# Altium schematic sheet DP83848I.SchDoc(DP83848I)
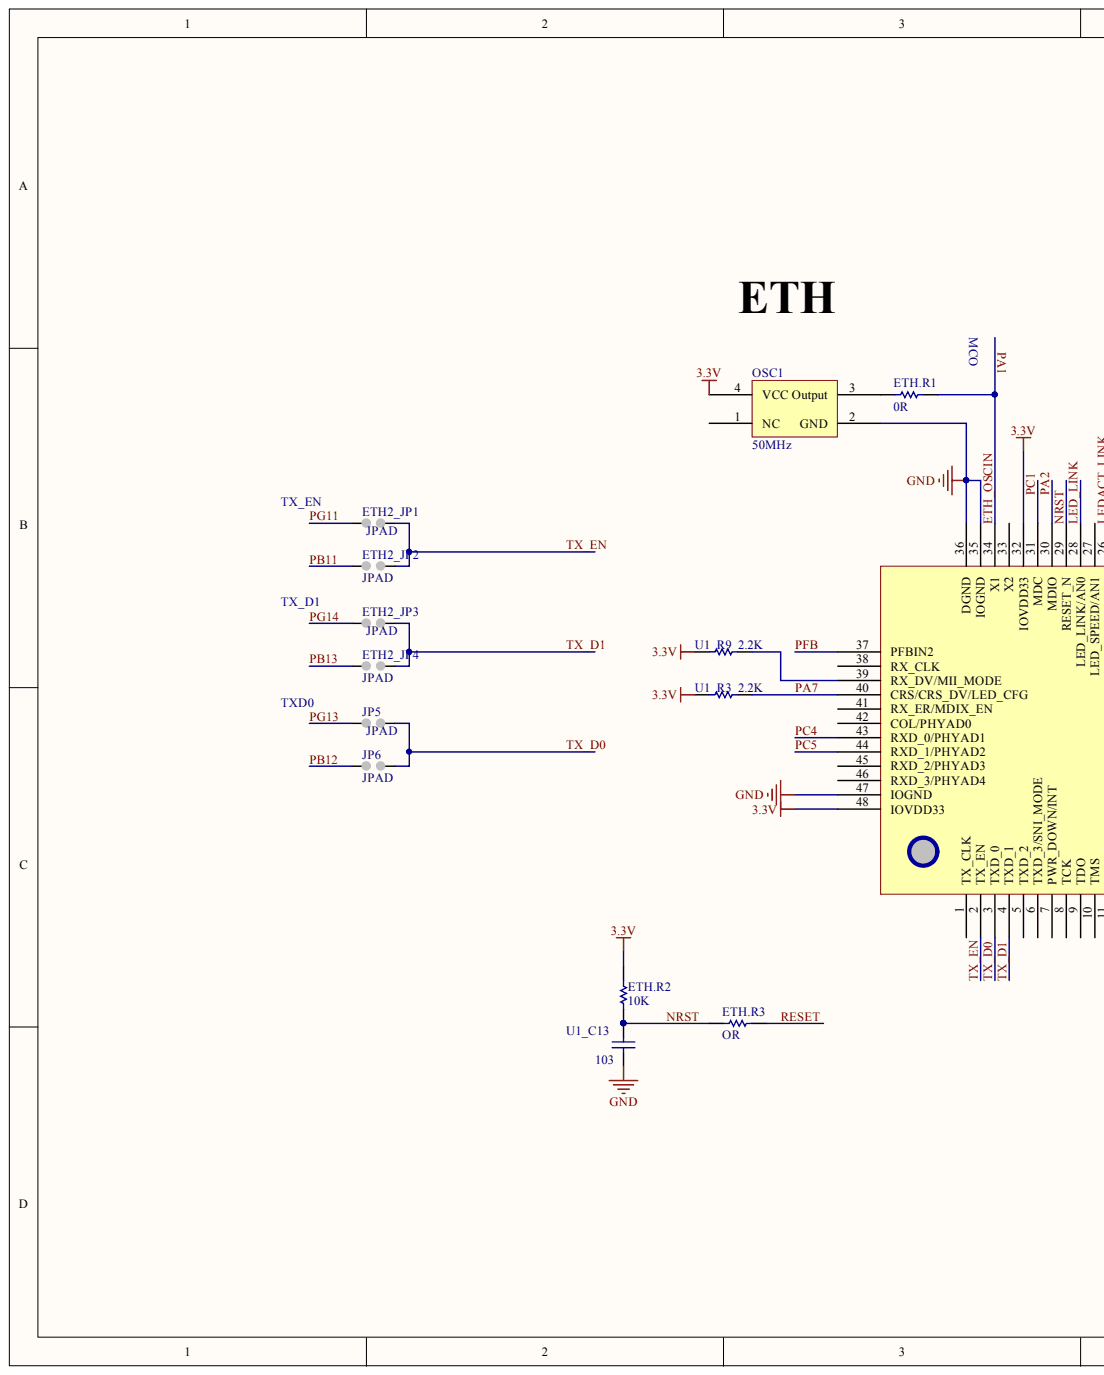
[diagram p0: DP83848I.SchDoc, left]
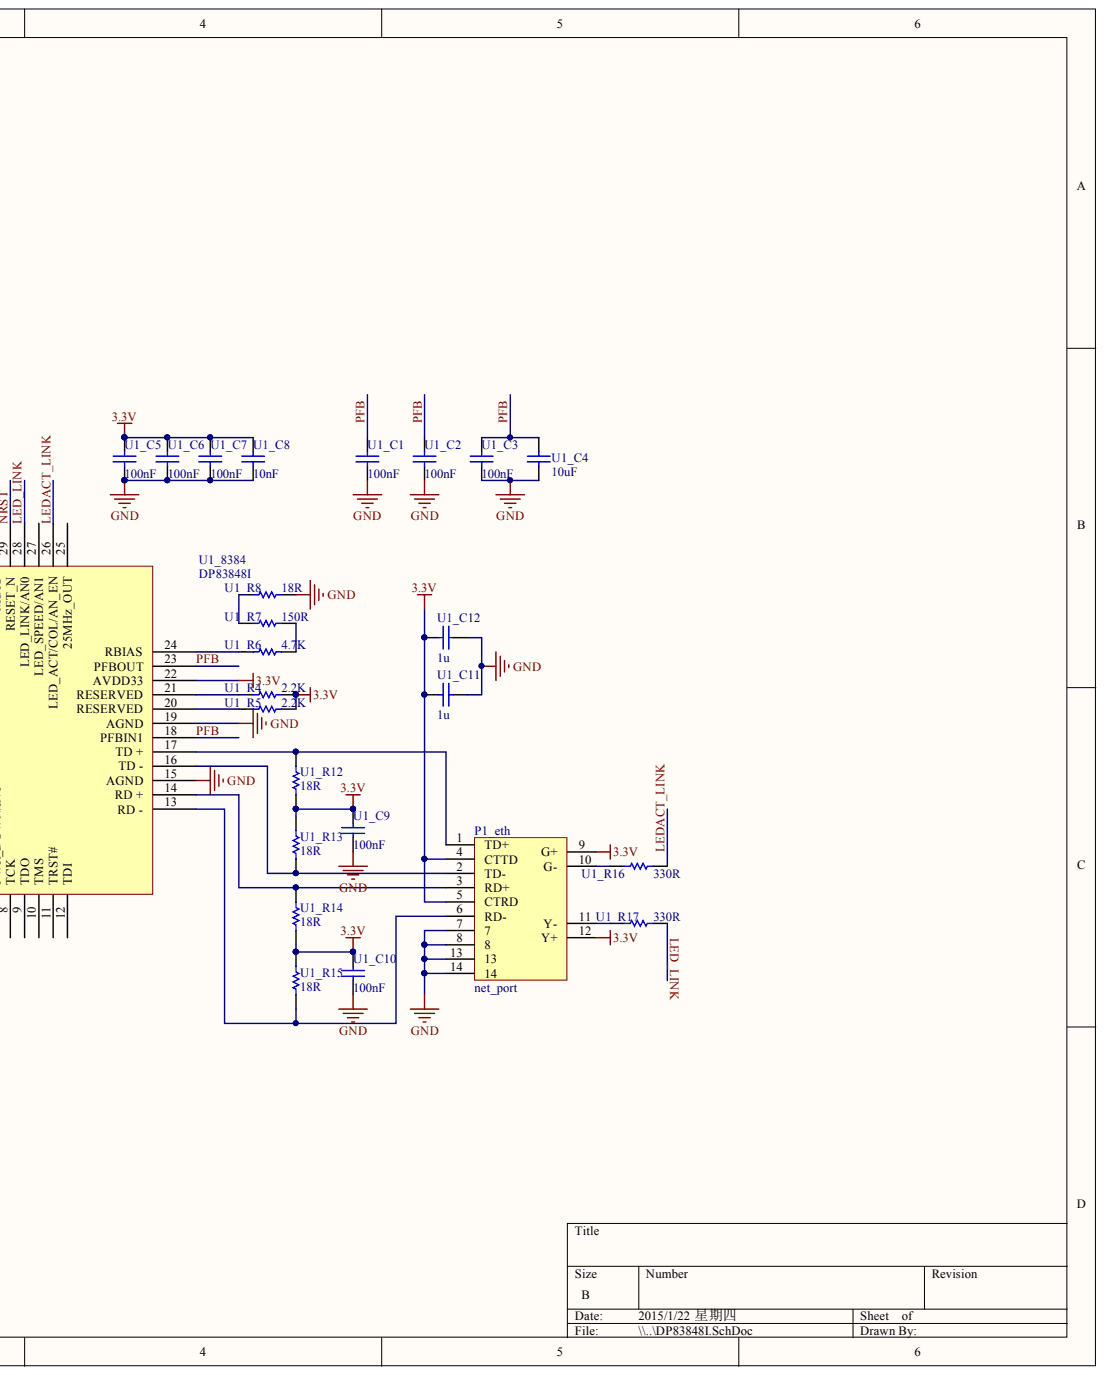
[diagram p1: DP83848I.SchDoc, right]

## Parts
ETH2_JP1  JPAD
ETH2_JP2  JPAD
ETH2_JP3  JPAD
ETH2_JP4  JPAD
ETH.R1  0R
ETH.R2  10K
ETH.R3  OR
JP5  JPAD
JP6  JPAD
OSC1  50MHz
P1_eth  net_port
U1_8384  DP83848I
U1_C1  100nF
U1_C2  100nF
U1_C3  100nF
U1_C4  10uF
U1_C5  100nF
U1_C6  100nF
U1_C7  100nF
U1_C8  10nF
U1_C9  100nF
U1_C10  100nF
U1_C11  value 1u  (hidden comment: C_0805)
U1_C12  value 1u  (hidden comment: C_0805)
U1_C13  value 103  (hidden comment: C_0805)
U1_R3  value 2.2K  (hidden comment: R_0805)
U1_R4  value 2.2K  (hidden comment: R_0805)
U1_R5  value 2.2K  (hidden comment: R_0805)
U1_R6  value 4.7K  (hidden comment: R_0805)
U1_R7  value 150R  (hidden comment: R_0805)
U1_R8  value 18R  (hidden comment: R_0805)
U1_R9  value 2.2K  (hidden comment: R_0805)
U1_R12  value 18R  (hidden comment: R_0805)
U1_R13  value 18R  (hidden comment: R_0805)
U1_R14  value 18R  (hidden comment: R_0805)
U1_R15  value 18R  (hidden comment: R_0805)
U1_R16  value 330R  (hidden comment: R_0805)
U1_R17  value 330R  (hidden comment: R_0805)

## Nets
3.3V: ETH.R2.2 OSC1.4(VCC) P1_eth.4(CTTD) P1_eth.5(CTRD) P1_eth.9(G+) P1_eth.12(Y+) U1_8384.22(AVDD33) U1_8384.32(IOVDD33) U1_8384.48(IOVDD33) U1_C5.2 U1_C6.2 U1_C7.2 U1_C8.2 U1_C9.2 U1_C10.2 U1_C11.2 U1_C12.2 U1_R3.1 U1_R4.2 U1_R5.2 U1_R9.1 U1_R12.1 U1_R13.2 U1_R14.1 U1_R15.1
GND: OSC1.2(GND) P1_eth.7 P1_eth.8 P1_eth.13 P1_eth.14 U1_8384.15(AGND) U1_8384.19(AGND) U1_8384.35(IOGND) U1_8384.36(DGND) U1_8384.47(IOGND) U1_C1.1 U1_C2.1 U1_C3.1 U1_C4.2 U1_C5.1 U1_C6.1 U1_C7.1 U1_C8.1 U1_C9.1 U1_C10.1 U1_C11.1 U1_C12.1 U1_C13.1 U1_R8.2
ETH_OSCIN: ETH.R1.1 U1_8384.34(X1)
LED_LINK: U1_8384.28(LED_LINK/AN0) U1_R17.2
LEDACT_LINK: U1_8384.26(LED_ACT/COL/AN_EN) U1_R16.2
NRST: ETH.R2.1 ETH.R3.2 U1_8384.29(RESET_N) U1_C13.2
PA2: U1_8384.30(MDIO)
PA7: U1_8384.40(CRS/CRS_DV/LED_CFG) U1_R3.2
PB11: ETH2_JP2.2
PB12: JP6.2
PB13: ETH2_JP4.2
PC1: U1_8384.31(MDC)
PC4: U1_8384.43(RXD_0/PHYAD1)
PC5: U1_8384.44(RXD_1/PHYAD2)
PFB: U1_8384.18(PFBIN1) U1_8384.23(PFBOUT) U1_8384.37(PFBIN2) U1_C1.2 U1_C2.2 U1_C3.2 U1_C4.1
PG11: ETH2_JP1.2
PG13: JP5.2
PG14: ETH2_JP3.2
RESET: ETH.R3.1
TX_D0: JP5.1 JP6.1 U1_8384.3(TXD_0)
TX_D1: ETH2_JP3.1 ETH2_JP4.1 U1_8384.4(TXD_1)
TX_EN: ETH2_JP1.1 ETH2_JP2.1 U1_8384.2(TX_EN)
NetETH.R1_2: ETH.R1.2 OSC1.3(Output)
NetP1_eth_1: P1_eth.1(TD+) U1_8384.17(TD_+) U1_R12.2
NetP1_eth_2: P1_eth.2(TD-) U1_8384.16(TD_-) U1_R13.1
NetP1_eth_3: P1_eth.3(RD+) U1_8384.14(RD_+) U1_R14.2
NetP1_eth_6: P1_eth.6(RD-) U1_8384.13(RD_-) U1_R15.2
NetP1_eth_10: P1_eth.10(G-) U1_R16.1
NetP1_eth_11: P1_eth.11(Y-) U1_R17.1
NetU1_8384_20: U1_8384.20(RESERVED) U1_R5.1
NetU1_8384_21: U1_8384.21(RESERVED) U1_R4.1
NetU1_8384_24: U1_8384.24(RBIAS) U1_R6.1
NetU1_8384_39: U1_8384.39(RX_DV/MII_MODE) U1_R9.2
NetU1_R6_2: U1_R6.2 U1_R7.2
NetU1_R7_1: U1_R7.1 U1_R8.1

Not connected: OSC1 1(NC); U1_8384 1(TX_CLK) 5(TXD_2) 6(TXD_3/SNI_MODE) 7(PWR_DOWN/INT) 8(TCK) 9(TDO) 10(TMS) 11(TRST#) 12(TDI) 25(25MHz_OUT) 27(LED_SPEED/AN1) 33(X2) 38(RX_CLK) 41(RX_ER/MDIX_EN) 42(COL/PHYAD0) 45(RXD_2/PHYAD3) 46(RXD_3/PHYAD4)

## Library data of the parts
ETH.R1-ETH.R3 = (no symbol name): Value: 1K
U1_C1-U1_C3 U1_C5-U1_C7 U1_C9 U1_C10 = (no symbol name): Value: 100n
U1_C8 = (no symbol name): Value: 10n
ETH2_JP1-ETH2_JP4 JP5 JP6 OSC1 P1_eth U1_8384 U1_C4 U1_C11-U1_C13 U1_R3-U1_R9 U1_R12-U1_R17 = (no symbol name)
  U1_C4: Value: 10uF
